# Altium schematic sheet PT100v1.SchDoc
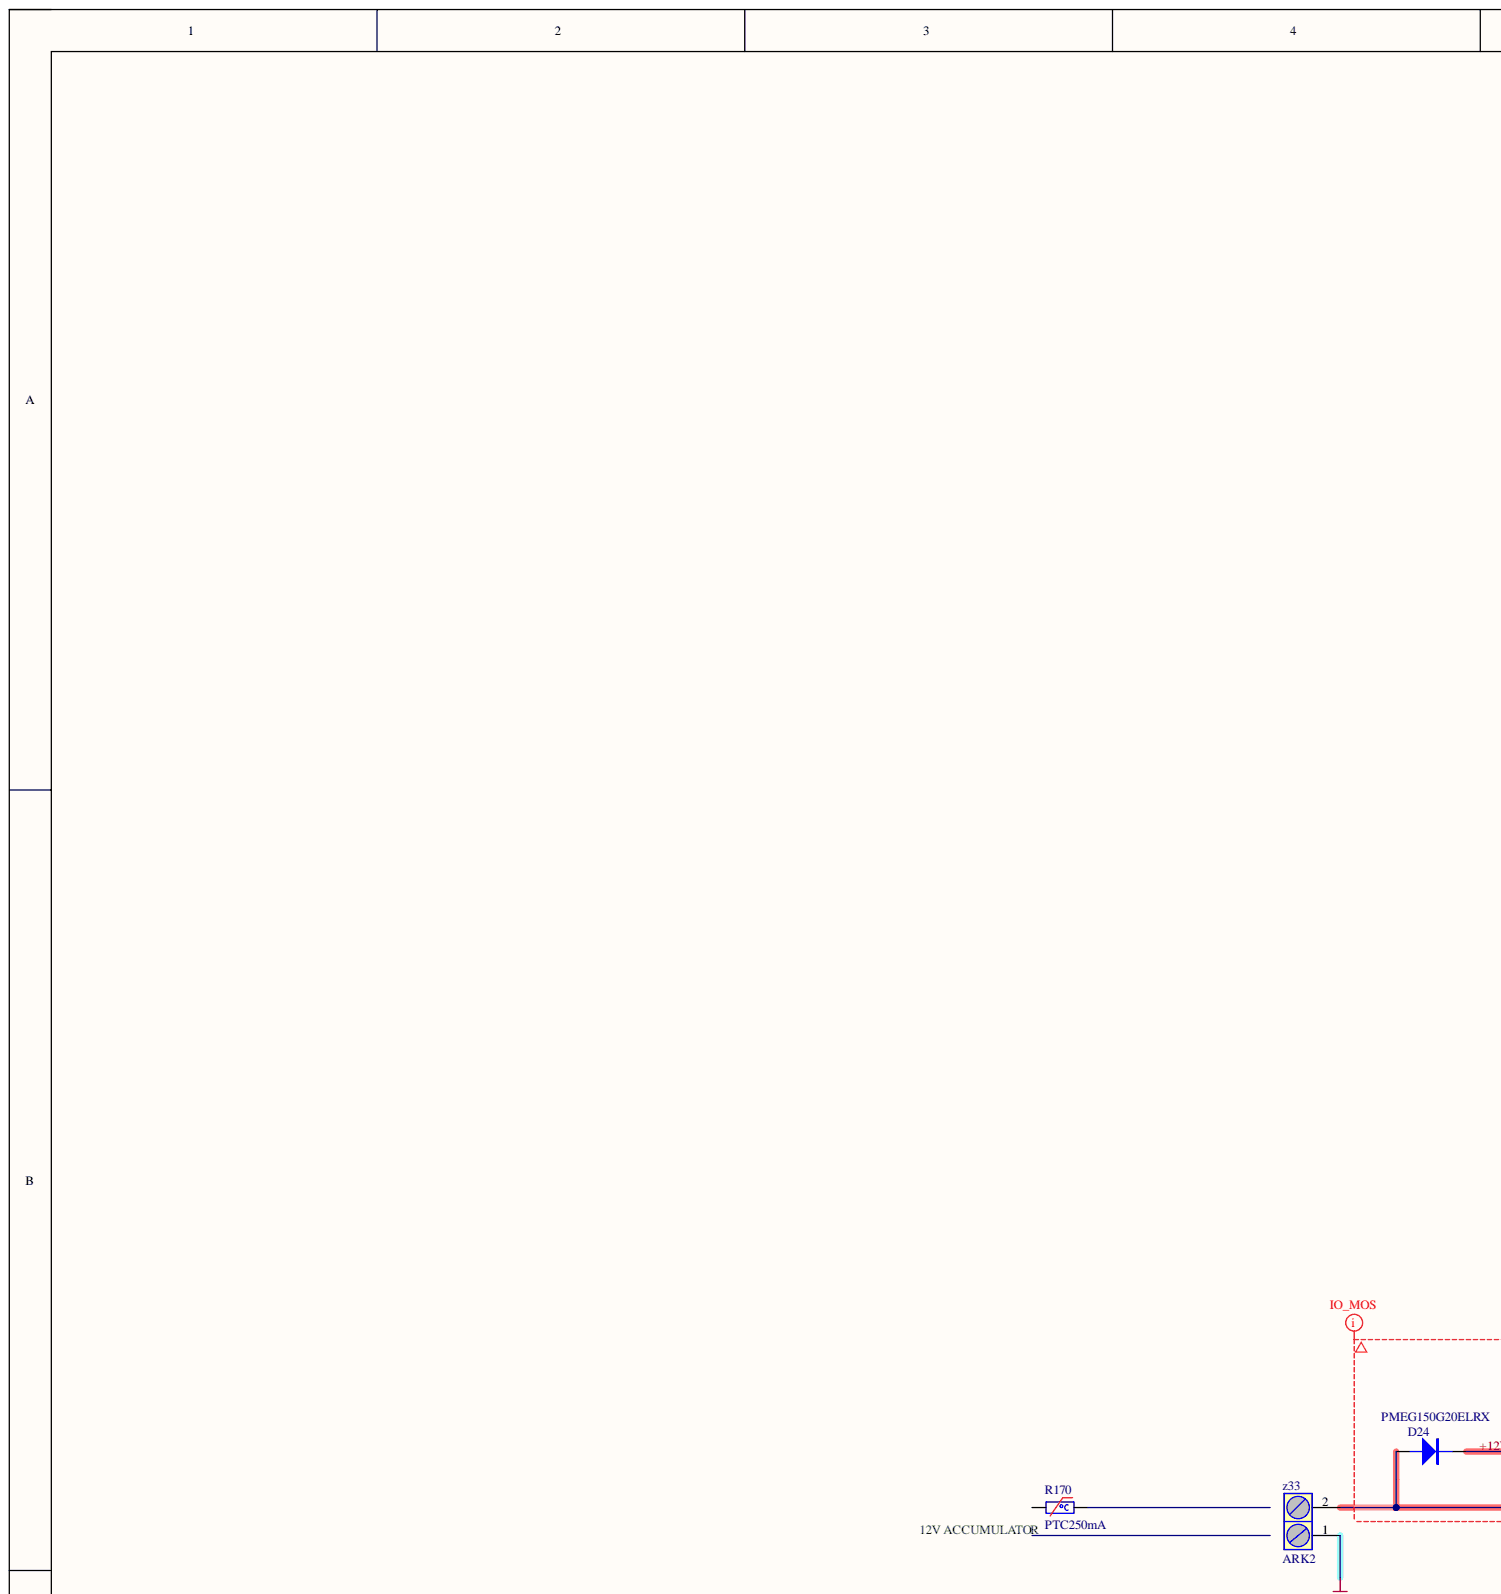
[diagram p0: PT100v1.SchDoc, top left]
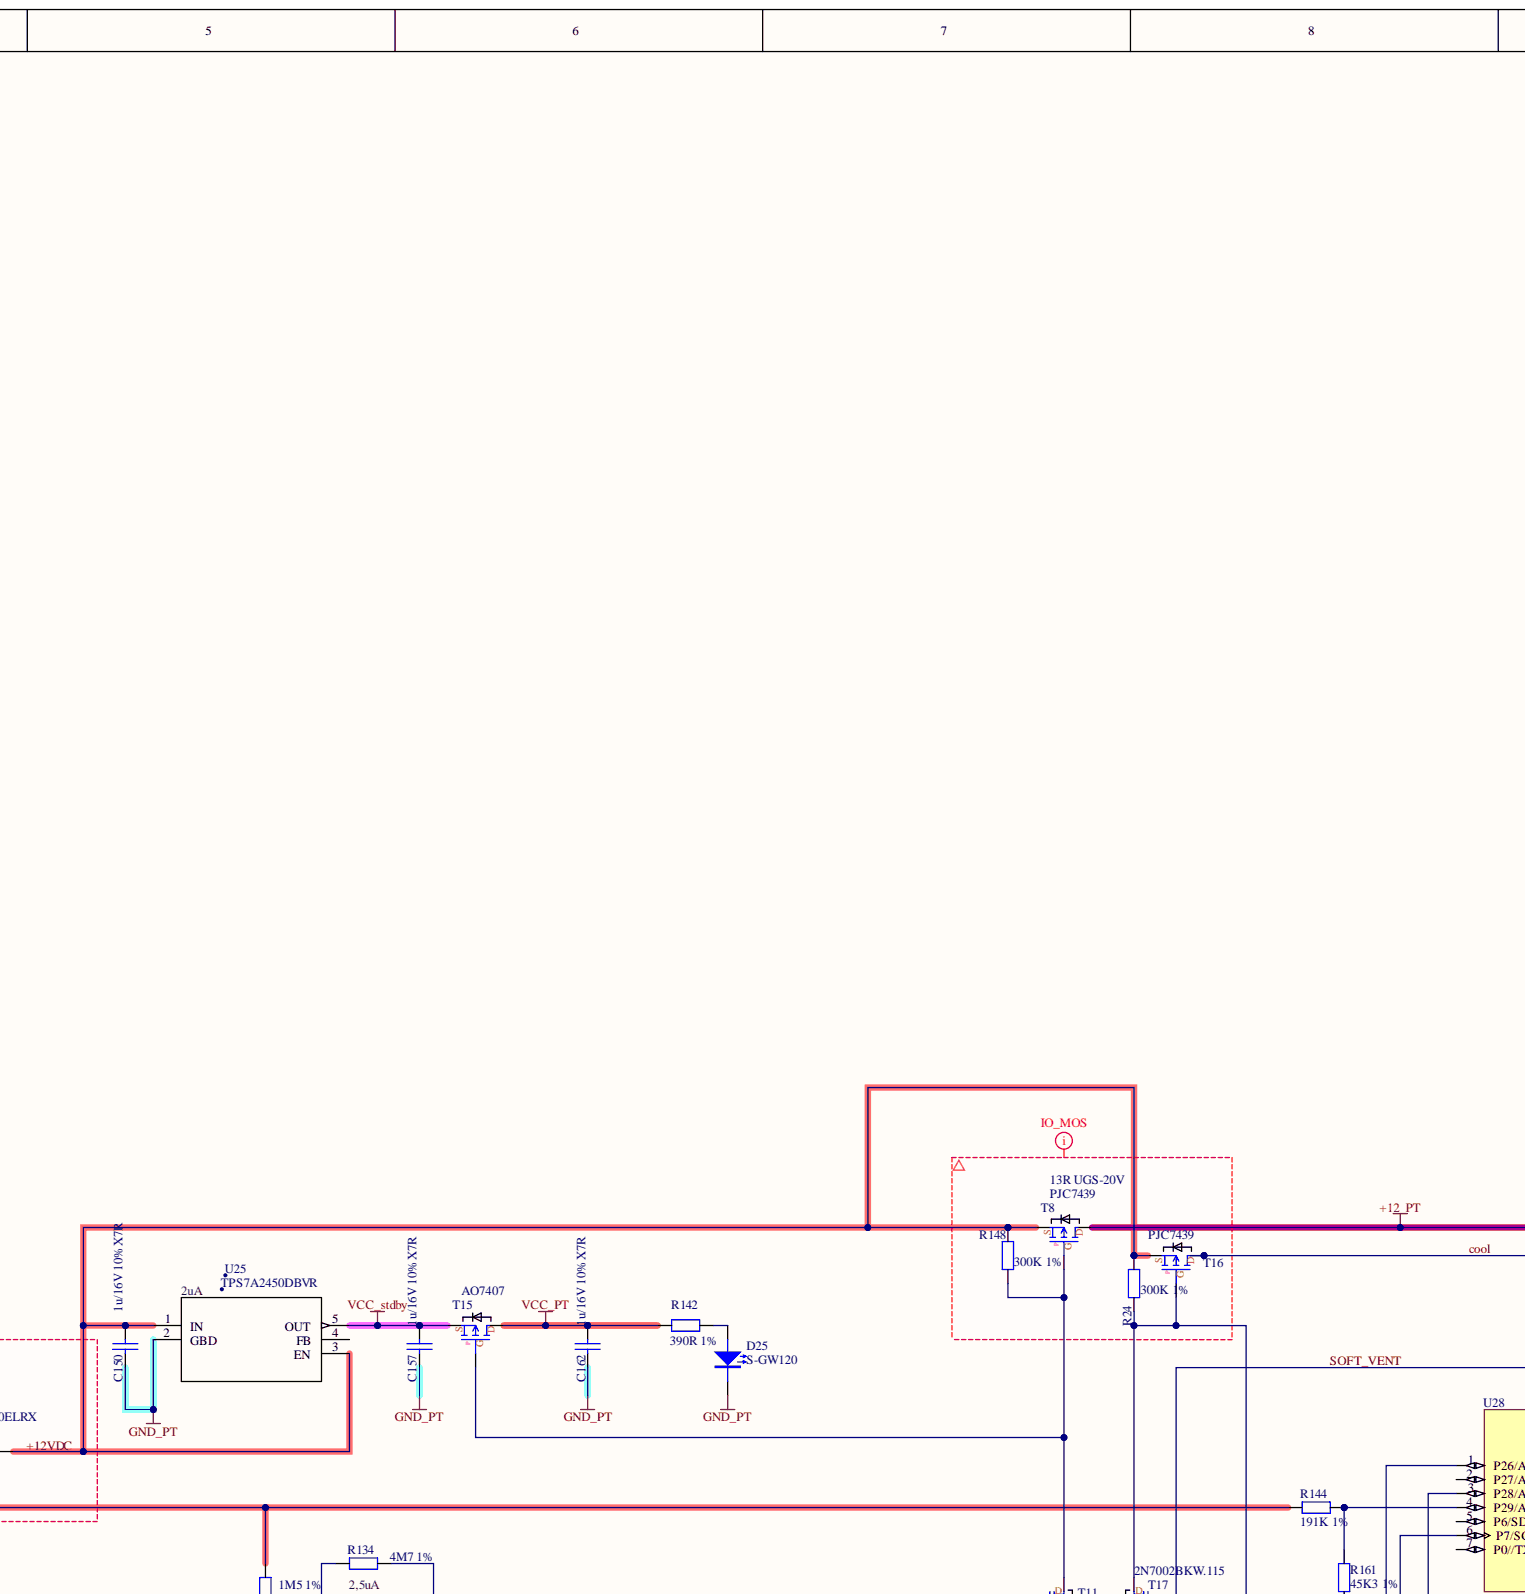
[diagram p1: PT100v1.SchDoc, top centre]
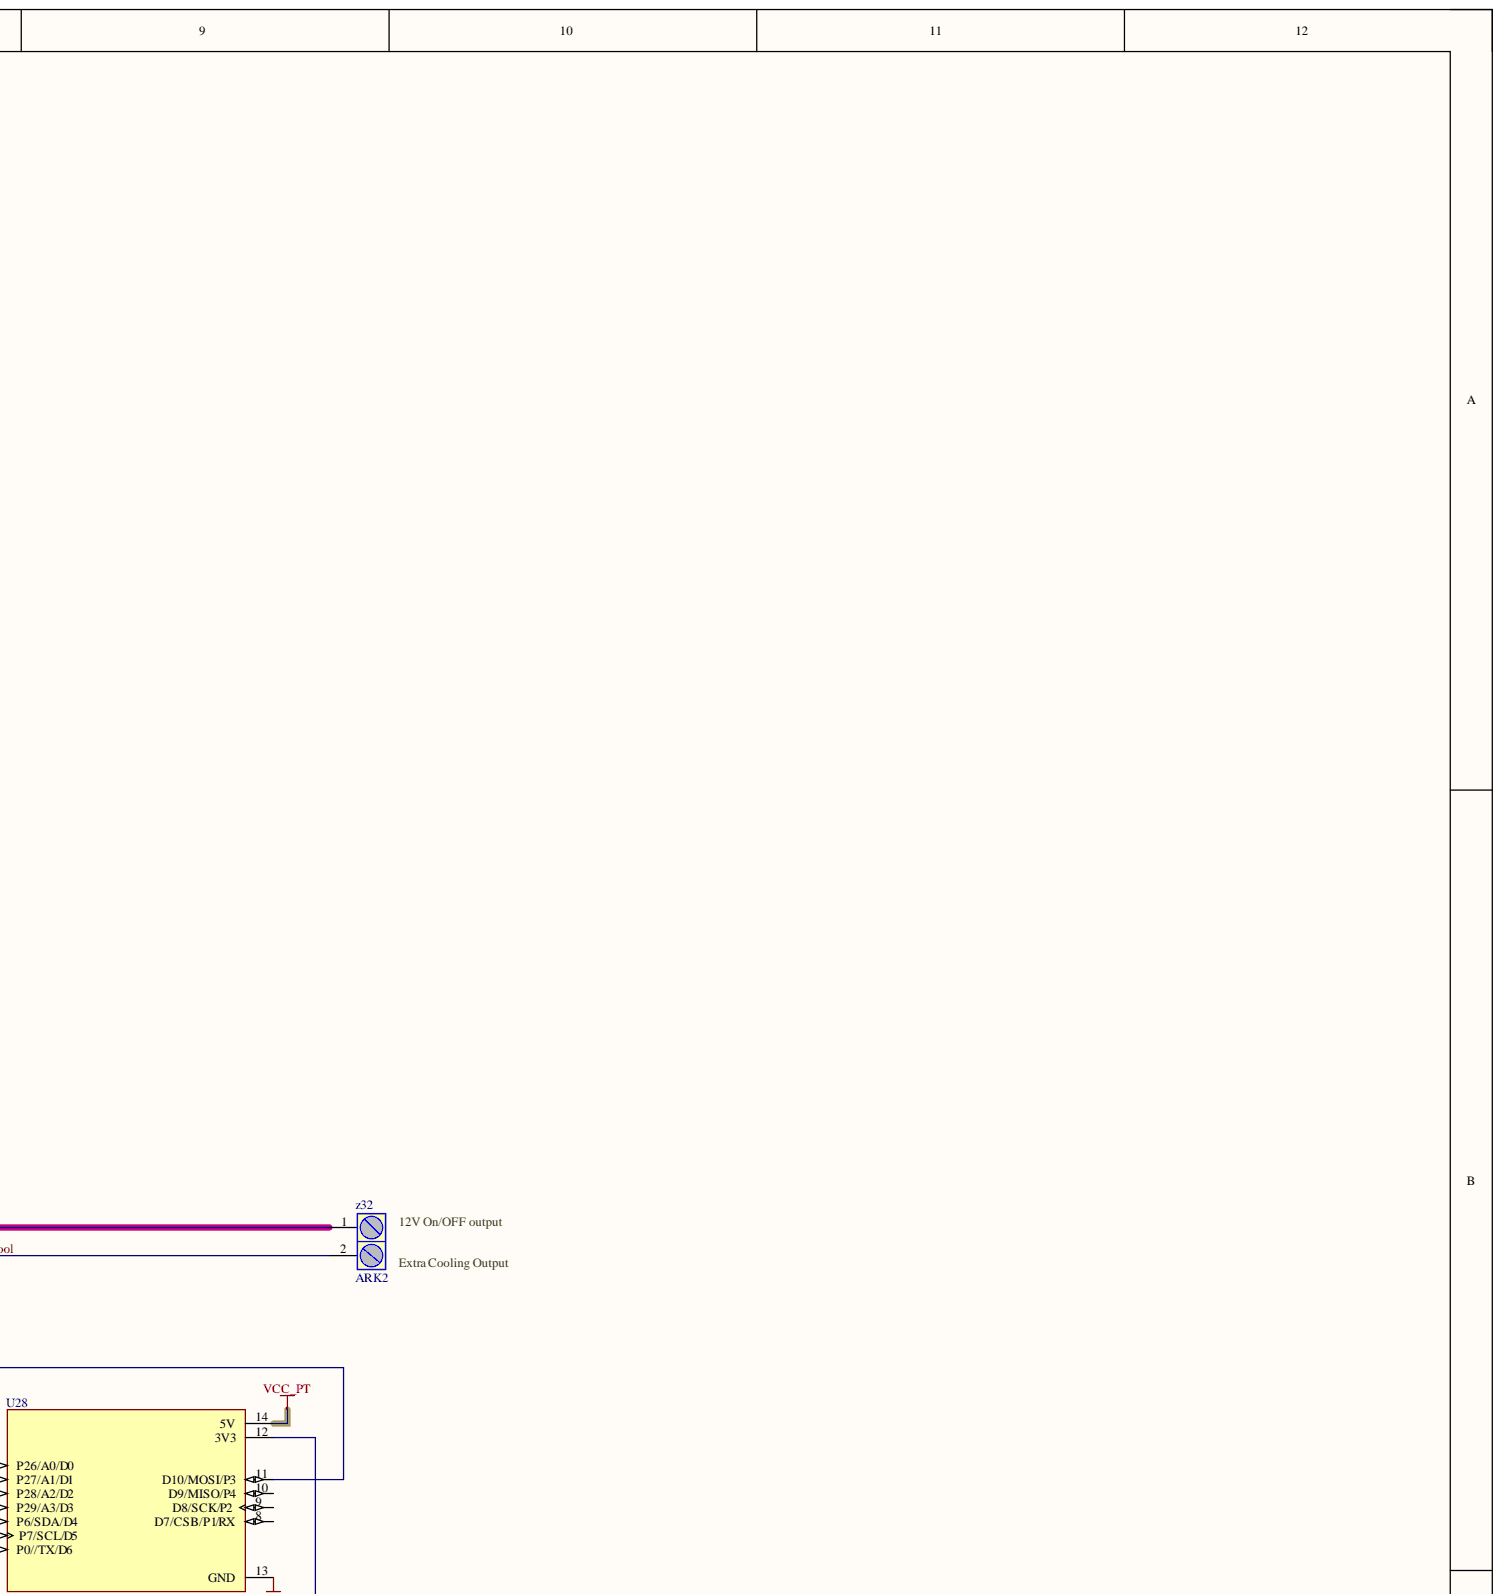
[diagram p2: PT100v1.SchDoc, top right]
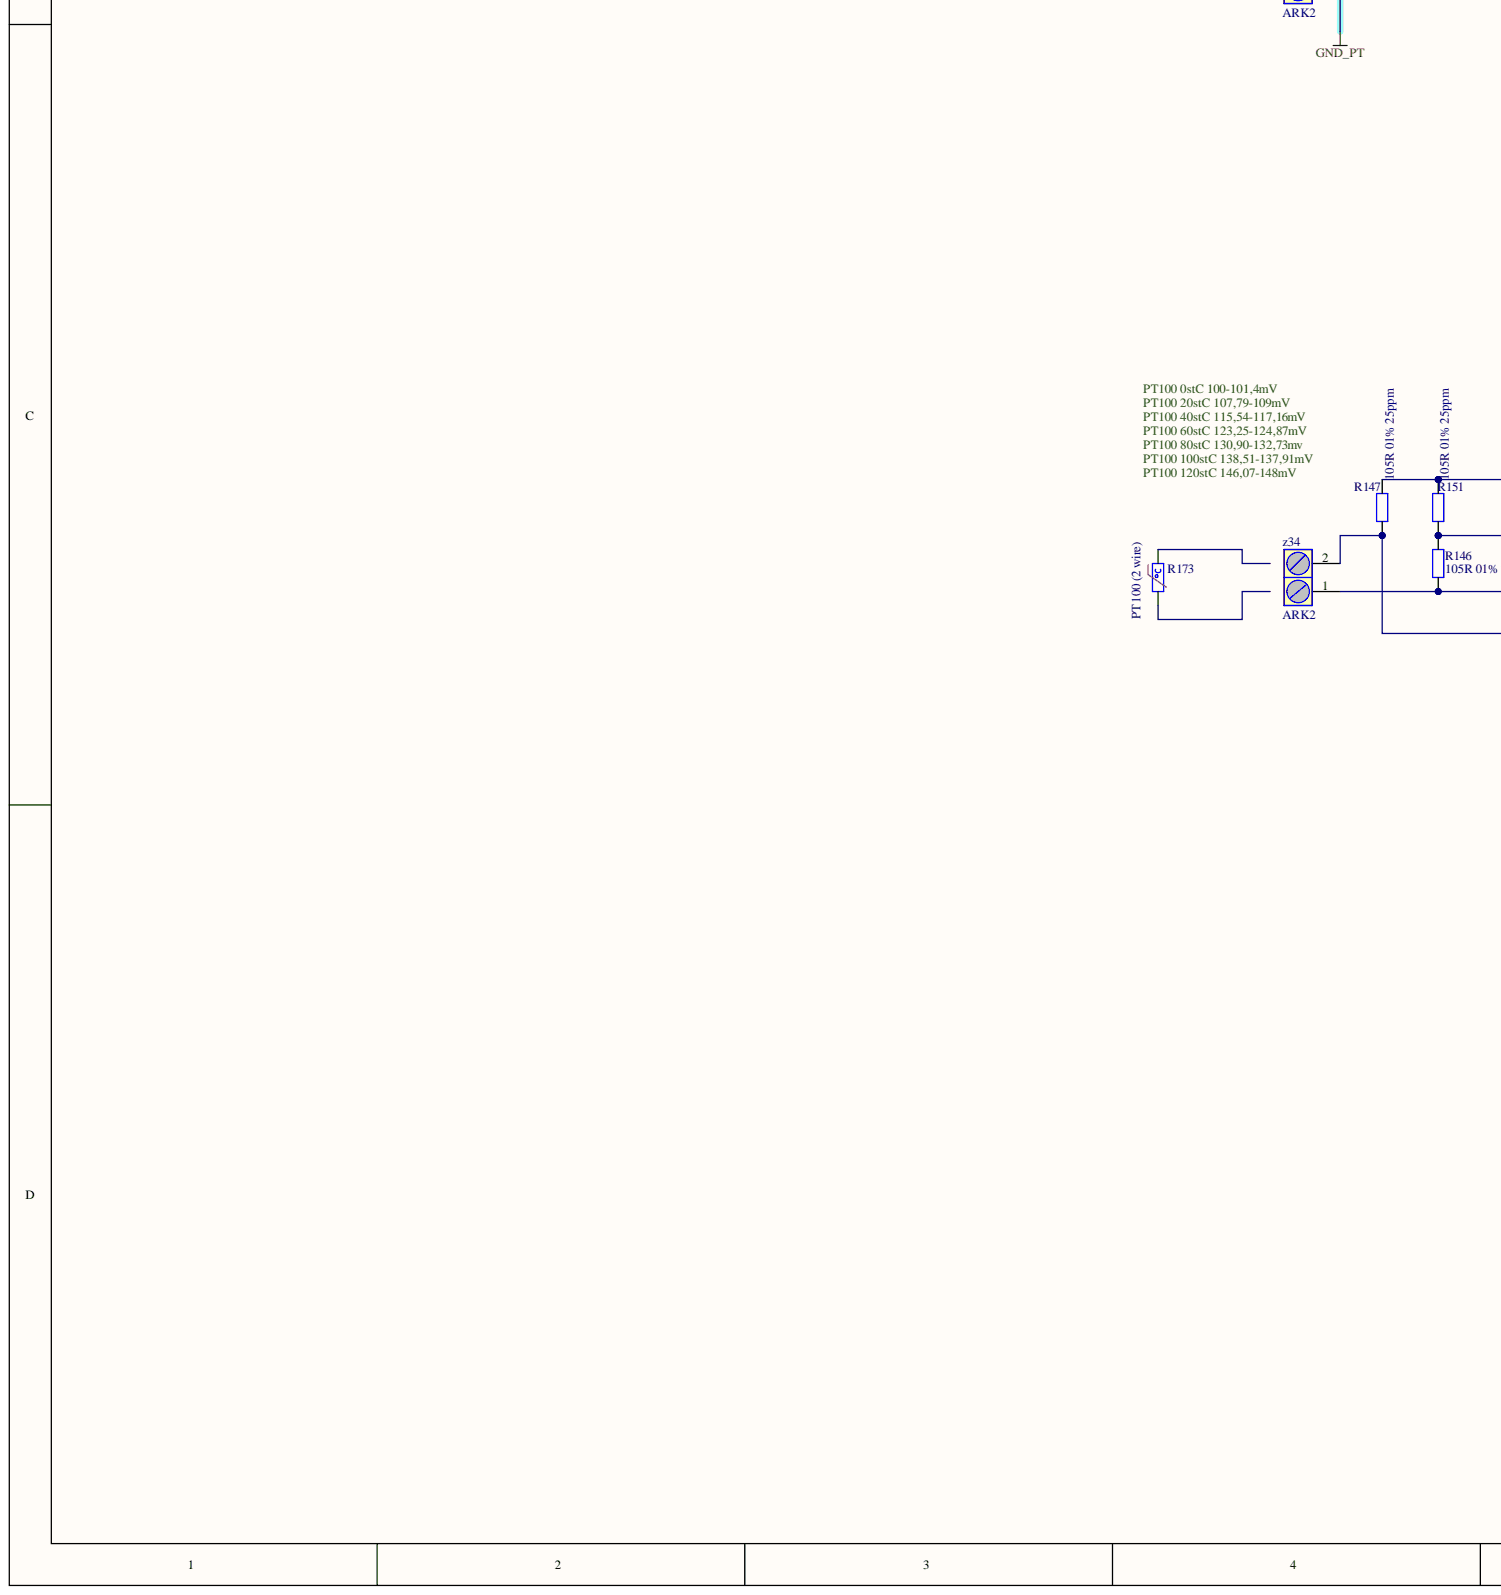
[diagram p3: PT100v1.SchDoc, bottom left]
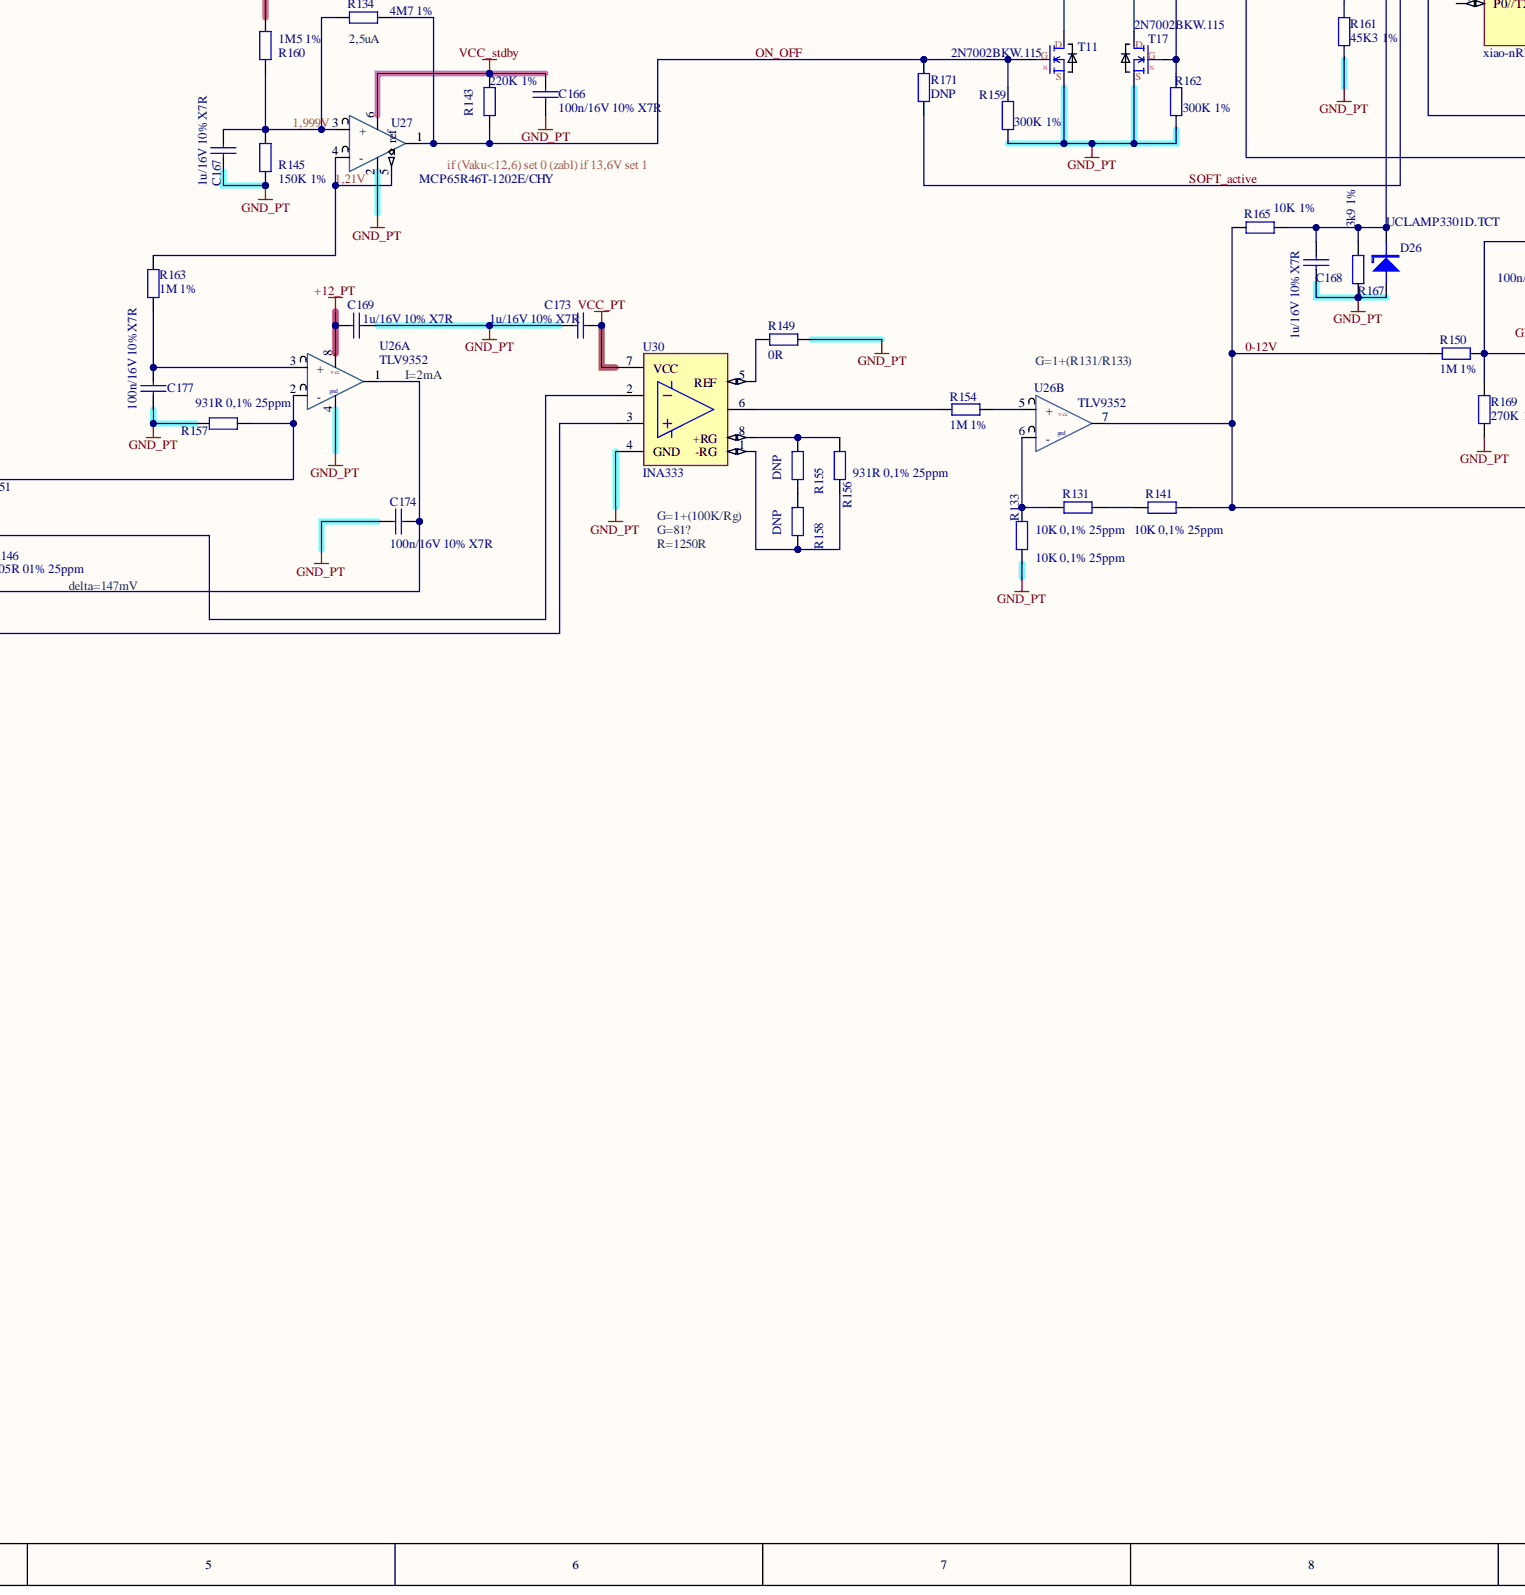
[diagram p4: PT100v1.SchDoc, bottom centre]
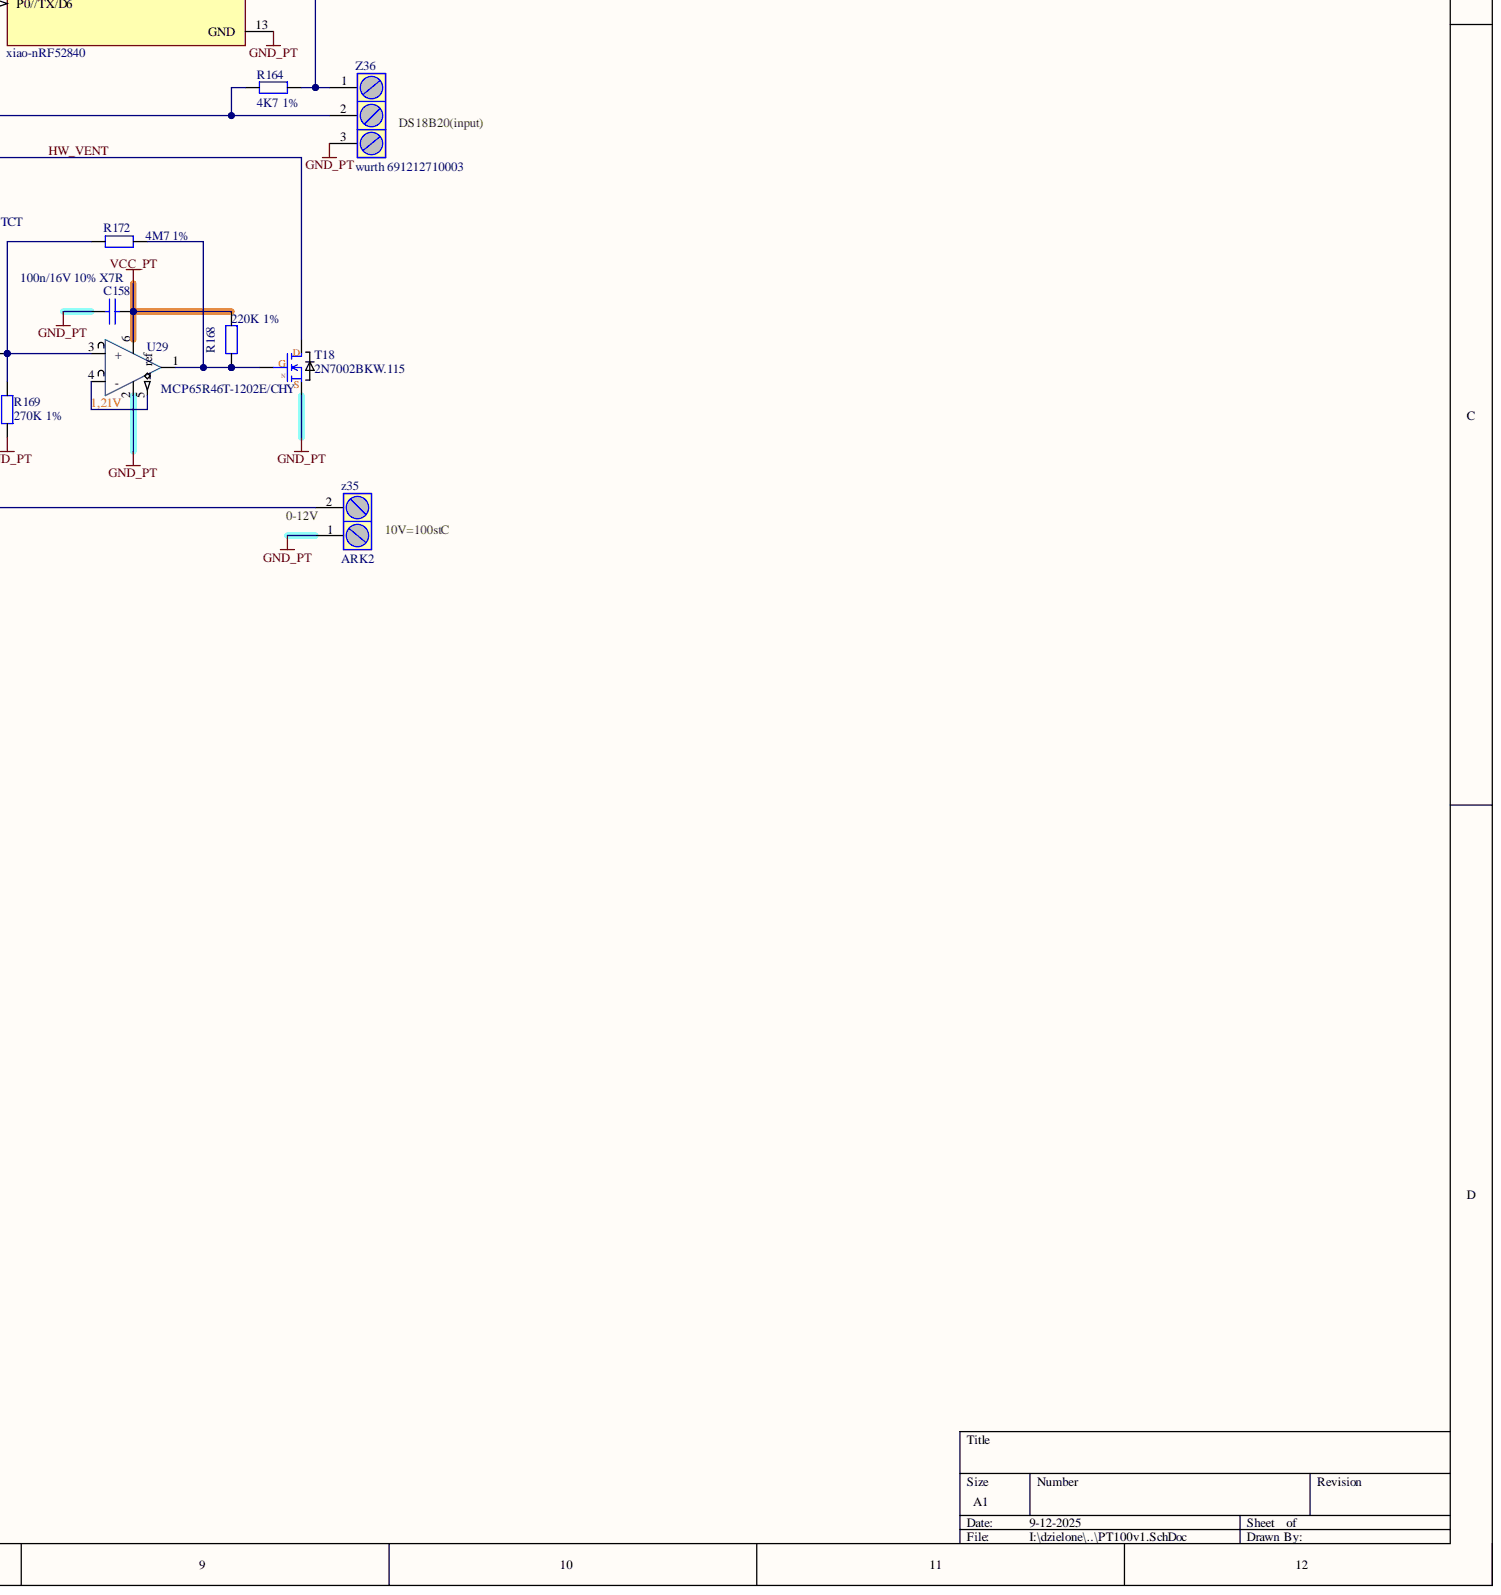
[diagram p5: PT100v1.SchDoc, bottom right]

## Parts
C150  1u/16V 10% X7R  [0603-CAP]
C157  1u/16V 10% X7R  [0603-CAP]
C158  100n/16V 10% X7R  [0603-CAP]
C162  1u/16V 10% X7R  [0603-CAP]
C166  100n/16V 10% X7R  [0603-CAP]
C167  1u/16V 10% X7R  [0603-CAP]
C168  1u/16V 10% X7R  [0603-CAP]
C169  1u/16V 10% X7R  [0603-CAP]
C173  1u/16V 10% X7R  [0603-CAP]
C174  100n/16V 10% X7R  [0603-CAP]
C177  100n/16V 10% X7R  [0603-CAP]
D24  PMEG150G20ELRX  [SOD123W]
D25  S-GW120  [0805-LED-G]
D26  UCLAMP3301D.TCT  [SOD323]
R24  300K 1%  [0402-REZ]
R131  10K 0,1% 25ppm  [0603-REZ]
R133  10K 0,1% 25ppm  [0603-REZ]
R134  4M7 1%  [0603-REZ]
R141  10K 0,1% 25ppm  [0603-REZ]
R142  390R 1%  [0603-REZ]
R143  220K 1%  [0603-REZ]
R144  191K 1%  [0603-REZ]
R145  150K 1%  [0603-REZ]
R146  105R 01% 25ppm  [0603-REZ]
R147  105R 01% 25ppm  [0603-REZ]
R148  300K 1%  [0402-REZ]
R149  0R  [0603-REZ]
R150  1M 1%  [0603-REZ]
R151  105R 01% 25ppm  [0603-REZ]
R154  1M 1%  [0603-REZ]
R155  DNP  [0603-REZ]
R156  931R 0,1% 25ppm  [0603-REZ]
R157  931R 0,1% 25ppm  [0603-REZ]
R158  DNP  [0603-REZ]
R159  300K 1%  [0402-REZ]
R160  1M5 1%  [0603-REZ]
R161  45K3 1%  [0603-REZ]
R162  300K 1%  [0402-REZ]
R163  1M 1%  [0603-REZ]
R164  4K7 1%  [0603-REZ]
R165  10K 1%  [0603-REZ]
R167  3k9 1%  [0603-REZ]
R168  220K 1%  [0603-REZ]
R169  270K 1%  [0603-REZ]
R170  PTC250mA  [0603]
R171  DNP  [0603-REZ]
R172  4M7 1%  [0603-REZ]
R173  PT100 (2 wire)  [0603]
T8  PJC7439  opis: 13R UGS-20V  [sot-323]
T11  2N7002BKW.115  [sot-323]
T15  AO7407  [sot-323]
T16  PJC7439  [sot-323]
T17  2N7002BKW.115  [sot-323]
T18  2N7002BKW.115  [sot-323]
U25  TPS7A2450DBVR  [SOT223-5]
U26  TLV9352  [msop8]  (parts A,B)
U27  MCP65R46T-1202E/CHY  [sot23-6 body2.2x2.7mm]
U28  xiao-nRF52840  [XiAO-nRF52840]
U29  MCP65R46T-1202E/CHY  [sot23-6 body2.2x2.7mm]
U30  INA333  [msop8]
z32  ARK2  [ARK2 5.0mm]
z33  ARK2  [ARK2 5.0mm]
z34  ARK2  [ARK2 5.0mm]
z35  ARK2  [ARK2 5.0mm]
Z36  wurth 691212710003  [ARK 3x2.54mm]

## Nets
+12_PT: C169.2 T8.2 U26.8 z32.1
GND_PT: C150.1 C157.1 C158.2 C162.1 C166.2 C167.1 C168.2 C169.1 C173.1 C174.2 C177.1 D25.2 D26.1 R133.1 R145.2 R149.2 R157.1 R159.2 R161.2 R162.2 R167.1 R169.1 T11.3 T17.3 T18.3 U25.2(GBD) U26.4 U27.2 U28.13(GND) U29.2 U30.4(GND) z33.1 z35.1 Z36.3
VCC_PT: C158.1 C162.2 C173.2 R142.2 R168.1 T15.2 U28.14(5V) U29.6 U30.7(VCC)
VCC_stdby: C157.2 C166.1 R143.1 T15.3 U25.5(OUT) U27.6
0-12V: R141.2 R150.2 R165.1 U26.7 z35.2
+12VDC: C150.2 D24.2 R24.1 R148.1 T8.3 T16.3 U25.1(IN) U25.3(EN)
cool: T16.2 z32.2
HW_VENT: R24.2 T16.1 T17.2 T18.2
ON_OFF: R134.2 R143.2 R159.1 R171.2 T11.1 U27.1
SOFT_active: R171.1 U28.6(P7/SCL/D5)
SOFT_VENT: R162.1 T17.1 U28.11(D10/MOSI/P3)
NetC167_2: C167.2 R134.1 R145.1 R160.2 U27.3(+)
NetC168_1: C168.1 D26.2 R165.2 R167.2 U28.1(P26/A0/D0)
NetC174_1: C174.1 R146.2 U26.1 z34.1
NetC177_2: C177.2 R163.1 U26.3(+)
NetD24_1: D24.1 R144.1 R160.1 z33.2
NetD25_1: D25.1 R142.1
NetR131_1: R131.1 R133.2 U26.6(-)
NetR131_2: R131.2 R141.1
NetR144_2: R144.2 R161.1 U28.4(P29/A3/D3)
NetR146_1: R146.1 R151.2 U30.2
NetR147_1: R147.1 U30.3 z34.2
NetR147_2: R147.2 R151.1 R157.2 U26.2(-)
NetR148_2: R148.2 T8.1 T11.2 T15.1
NetR149_1: R149.1 U30.5(REF)
NetR150_1: R150.1 R169.2 R172.1 U29.3(+)
NetR154_1: R154.1 U26.5(+)
NetR154_2: R154.2 U30.6
NetR155_1: R155.1 R156.1 U30.8(+RG)
NetR155_2: R155.2 R158.1
NetR156_2: R156.2 R158.2 U30.1(-RG)
NetR163_2: R163.2 U27.4(-) U27.5(ref)
NetR164_1: R164.1 U28.3(P28/A2/D2) Z36.2
NetR164_2: R164.2 U28.12(3V3) Z36.1
NetR168_2: R168.2 R172.2 T18.1 U29.1
NetU29_4: U29.4(-) U29.5(ref)

Not connected: R170 1 2; R173 1 2; U25 4(FB); U28 2(P27/A1/D1) 5(P6/SDA/D4) 7(P0//TX/D6) 8(D7/CSB/P1/RX) 9(D8/SCK/P2) 10(D9/MISO/P4)

## Library data of the parts
C150 C157 C158 C162 C166-C169 C173 C174 C177 = CAP: C; Component Kind: Standard
  C174 C177: Library Name: PAWELsch.SCHLIB
D24 = DIODE: 1A 100V (min); Component Kind: Standard; Library Name: PAWELsch.SCHLIB
D25 = LED: Component Kind: Standard; Description: LED; Library Name: PAWELsch.SCHLIB
D26 = ZENER: dioda zenera 3.3V; Component Kind: Standard; Library Name: PAWELsch.SCHLIB
R24 R131 R133 R134 R141-R151 R154-R165 R167-R169 R171 R172 = REZ: Component Kind: Standard; Description: REZ; Library Name: PAWELsch.SCHLIB
R170 R173 = termistor: REZ; Component Kind: Standard; Library Name: PAWELsch.SCHLIB
T8 T15 T16 = P-MOS: Component Kind: Standard; Library Name: PAWELsch.SCHLIB
T11 T17 T18 = n-mos: Component Kind: Standard; Library Name: PAWELsch.SCHLIB
U25 = TPS7A2401DBVR: 200-mA, 18-V, ultra-low-IQ, low-dropout (LDO) voltage regulator with enable; MF: Texas Instruments; Check_prices: https://www.snapeda.com/parts/TPS7A2401DBVR/Texas+Instruments/view-part/?ref=eda; Component Kind: Standard; Library Name: TPS7A2401DBVR.IntLib; MP: TPS7A2401DBVR; Package: SOT-23-5 Texas Instruments; SnapEDA_Link: https://www.snapeda.com/parts/TPS7A2401DBVR/Texas+Instruments/view-part/?ref=snap
U26 = TLV9352: dual op ampl 40V TLV9352IDGKR; Component Kind: Standard; Library Name: PAWELsch.SCHLIB
U27 U29 = MCP65R41T: komparator +ref; Component Kind: Standard; Library Name: PAWELsch.SCHLIB
U28 = 102010428: MF: Seeed Technology Co., Ltd; Check_prices: https://www.snapeda.com/parts/102010428/Seeed+Technology+Co.%252C+Ltd/view-part/?ref=eda; Component Kind: Standard; Description: xiao-nRF52840; Library Name: 102010428.IntLib; MP: 102010428; Package: None; SnapEDA_Link: https://www.snapeda.com/parts/102010428/Seeed+Technology+Co.%252C+Ltd/view-part/?ref=snap
U30 = AD623: Component Kind: Standard; Description: AD623; Library Name: PAWELsch.SCHLIB
z35 = ARK2: dostawca: wurth 691502710002; Component Kind: Standard; Library Name: PAWELsch.SCHLIB
Z36 = ARK3: Component Kind: Standard; Library Name: PAWELsch.SCHLIB
z32-z34 = ARK2: Component Kind: Standard; Library Name: PAWELsch.SCHLIB
